# Altium schematic sheet bias supply.SchDoc
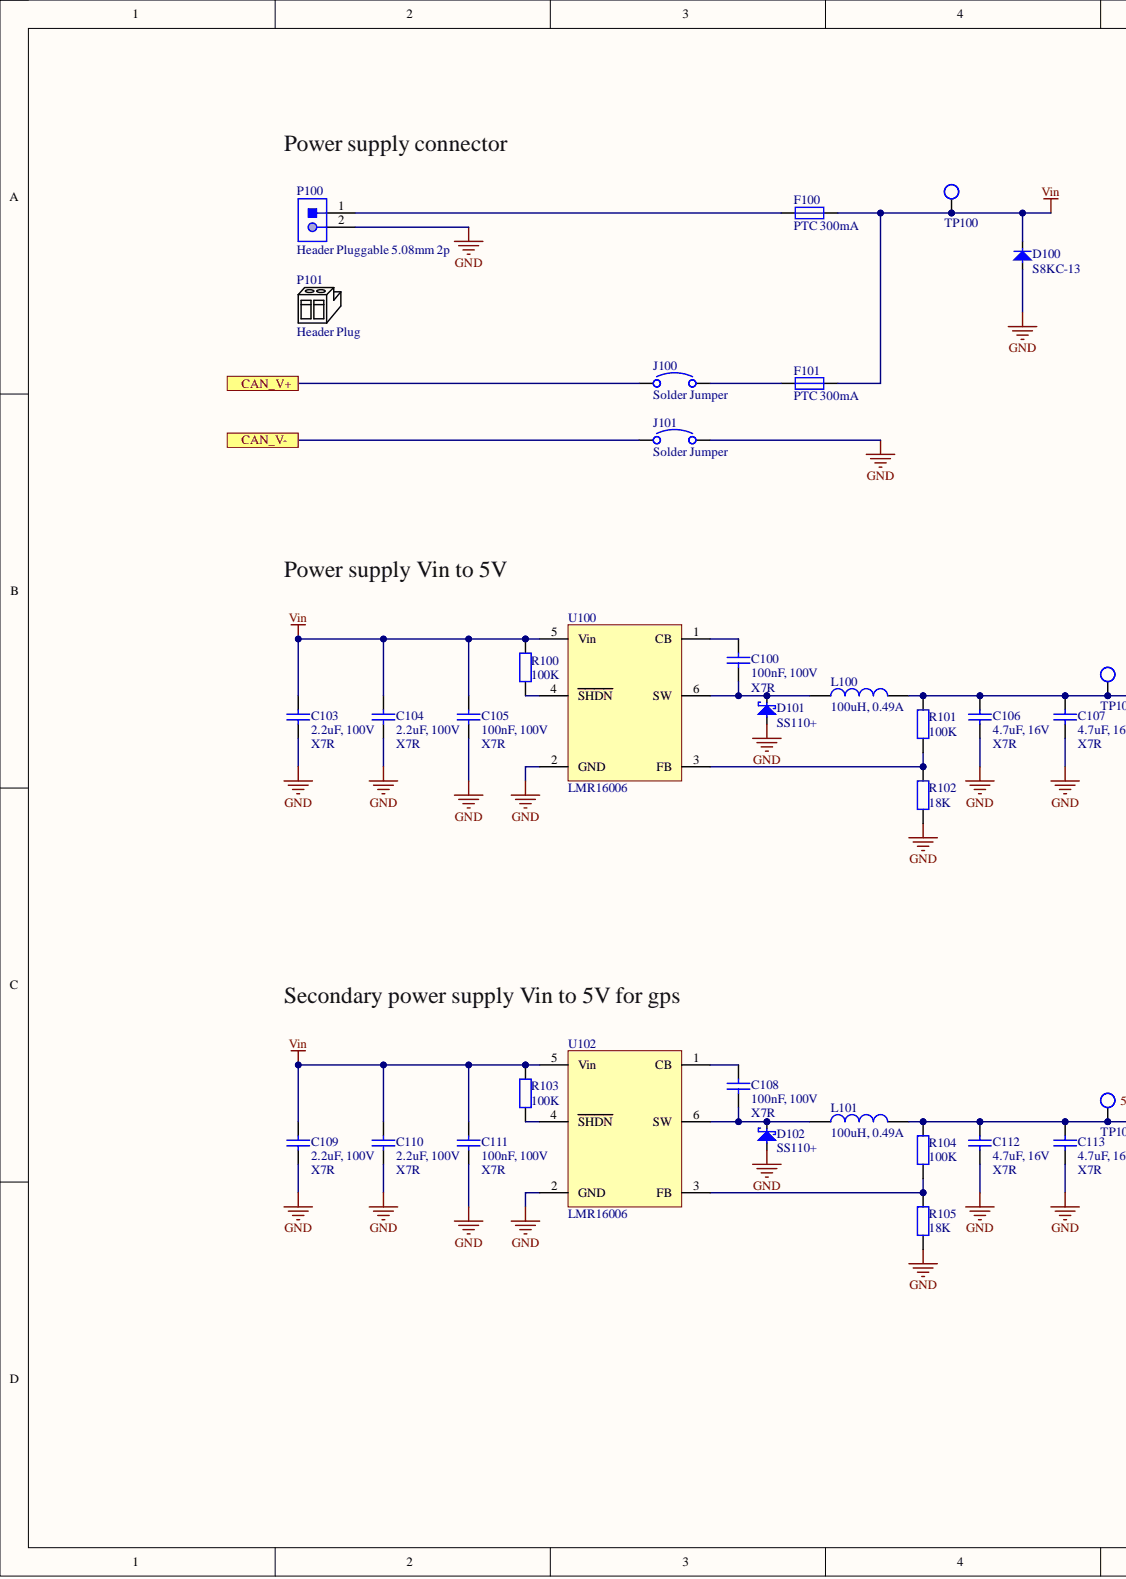
[diagram p0: bias supply.SchDoc, left]
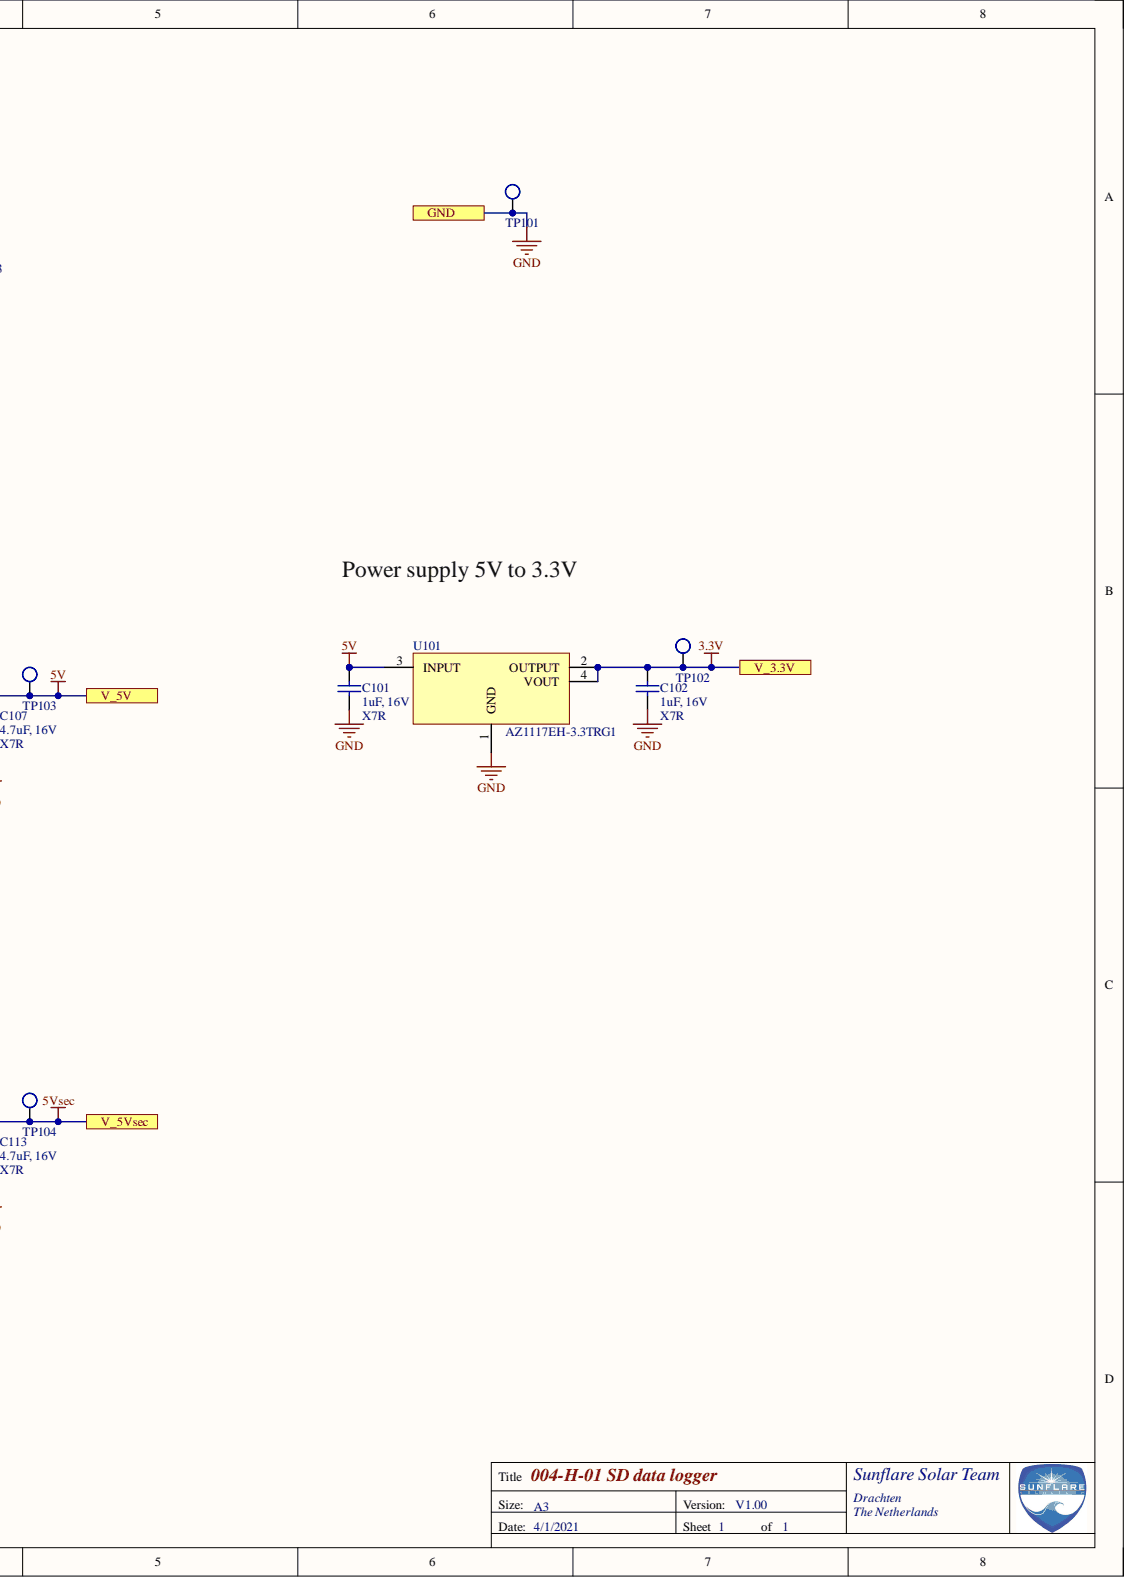
[diagram p1: bias supply.SchDoc, right]

## Parts
C100  100nF, 100V  Ceramic Type: X7R  [CAP_0805]
C101  1uF, 16V  Ceramic Type: X7R  [CAP_0805]
C102  1uF, 16V  Ceramic Type: X7R  [CAP_0805]
C103  2.2uF, 100V  Ceramic Type: X7R  [CAP_1210]
C104  2.2uF, 100V  Ceramic Type: X7R  [CAP_1210]
C105  100nF, 100V  Ceramic Type: X7R  [CAP_0805]
C106  4.7uF, 16V  Ceramic Type: X7R  [CAP_0805]
C107  4.7uF, 16V  Ceramic Type: X7R  [CAP_0805]
C108  100nF, 100V  Ceramic Type: X7R  [CAP_0805]
C109  2.2uF, 100V  Ceramic Type: X7R  [CAP_1210]
C110  2.2uF, 100V  Ceramic Type: X7R  [CAP_1210]
C111  100nF, 100V  Ceramic Type: X7R  [CAP_0805]
C112  4.7uF, 16V  Ceramic Type: X7R  [CAP_0805]
C113  4.7uF, 16V  Ceramic Type: X7R  [CAP_0805]
D100  S8KC-13  [DIODEDO-214AB]
D101  SS110+  [DIODEDO-214AC]
D102  SS110+  [DIODEDO-214AC]
F100  PTC 300mA  [PTC7451X12N]
F101  PTC 300mA  [PTC7451X12N]
J100  Solder Jumper  [SolderJumer]  (no BOM)
J101  Solder Jumper  [SolderJumer]  (no BOM)
L100  100uH, 0.49A  Rated Current: 0.49A  [WE-LQS_4025]
L101  100uH, 0.49A  Rated Current: 0.49A  [WE-LQS_4025]
P100  Header Pluggable 5.08mm 2p  Application: Pluggable  [WE-691313510002]
P101  Header Plug  Type: Plug  (mechanical)
R100  100K  [RES_0805]
R101  100K  [RES_0805]
R102  18K  [RES_0805]
R103  100K  [RES_0805]
R104  100K  [RES_0805]
R105  18K  [RES_0805]
TP100  Test Pad  [TestPad]  (value not drawn)  (no BOM)
TP101  Test Pad  [TestPad]  (value not drawn)  (no BOM)
TP102  Test Pad  [TestPad]  (value not drawn)  (no BOM)
TP103  Test Pad  [TestPad]  (value not drawn)  (no BOM)
TP104  Test Pad  [TestPad]  (value not drawn)  (no BOM)
U100  LMR16006  [SOT95P280X110-6N]
U101  AZ1117EH-3.3TRG1  [FP-SOT223-IPC_A]
U102  LMR16006  [SOT95P280X110-6N]

## Ports
CAN_V+, CAN_V-, GND, V_3.3V, V_5V, V_5Vsec

## Nets
Vin: C103.2 C104.1 C105.2 C109.2 C110.1 C111.2 D100.1 F100.2 F101.2 R100.2 R103.2 TP100.1 U100.5(Vin) U102.5(Vin)
3.3V: C102.1 TP102.1 U101.2(OUTPUT) U101.4(VOUT) ; port V_3.3V
5V: C101.2 C106.2 C107.1 L100.2 R101.2 TP103.1 U101.3(INPUT) ; port V_5V
5Vsec: C112.2 C113.1 L101.2 R104.2 TP104.1 ; port V_5Vsec
GND: C101.1 C102.2 C103.1 C104.2 C105.1 C106.1 C107.2 C109.1 C110.2 C111.1 C112.1 C113.2 D100.2 D101.2 D102.2 J101.2 P100.2 R102.1 R105.1 TP101.1 U100.2(GND) U101.1(GND) U102.2(GND) ; port GND
NetC100_1: C100.1 U100.1(CB)
NetC100_2: C100.2 D101.1 L100.1 U100.6(SW)
NetC108_1: C108.1 U102.1(CB)
NetC108_2: C108.2 D102.1 L101.1 U102.6(SW)
NetF100_1: F100.1 P100.1
NetF101_1: F101.1 J100.2
NetJ100_1: J100.1
NetJ101_1: J101.1
NetR100_1: R100.1 U100.4(SHDN)
NetR101_1: R101.1 R102.2 U100.3(FB)
NetR103_1: R103.1 U102.4(SHDN)
NetR104_1: R104.1 R105.2 U102.3(FB)

## Library data of the parts
C100 C105 C108 C111 = CAP_0805_100nF_100V: WCAP-CSGP Multilayer Ceramic Chip Capacitor, General Purpose, size 0805, X7R, 100nF, 100V; Manufacturer: Wurth Elektronik; Manufacturer Part Number: 885012207128; Capacitance Tolerance: +/-10%; Dissipation Factor: #NAME?; Isolation Resistance: >=1GOhm; Rated Voltage: 100VDC; Technical Reference: X7R0805104K100DFCT10000; Capacitance: 100nF; Component Kind: Standard; ComponentLink1Description: Manufacturer; ComponentLink1URL: http://www.we-online.de; ComponentLink2Description: Datasheet; ComponentLink2URL: https://www.we-online.com/catalog/datasheet/885012207128.pdf; Height: 1.25mm; Library Name: 004-H-01 SD data logger.SchLib; Mounting Technology: SMT; Operating Temperature: -55 to +125degC; Packaging: Tape and Reel; Published Date: May 2020; Size: 0805; Supplier 1: Farnell; Supplier Part Number 1: 2812440
C101 C102 = CAP_0805_1uF_16V: WCAP-CSGP Multilayer Ceramic Chip Capacitor, General Purpose, size 0805, X7R, 1uF, 16V; Manufacturer: Wurth Elektronik; Manufacturer Part Number: 885012207051; Capacitance Tolerance: +/-10%; Dissipation Factor: #NAME?; Isolation Resistance: >=0.5GOhm; Rated Voltage: 16VDC; Technical Reference: X7R0805105K016DFCT10000; Capacitance: 1uF; Component Kind: Standard; ComponentLink1Description: Manufacturer; ComponentLink1URL: http://www.we-online.de; ComponentLink2Description: Datasheet; ComponentLink2URL: https://www.we-online.com/catalog/datasheet/885012207051.pdf; Height: 1.25mm; Library Name: 004-H-01 SD data logger.SchLib; Mounting Technology: SMT; Operating Temperature: -55 to +125degC; Packaging: Tape and Reel; Published Date: May 2020; Size: 0805; Supplier 1: Farnell; Supplier Part Number 1: 2534058
C103 C104 C109 C110 = CAP_1210_2.2uF_100V: WCAP-CSGP Multilayer Ceramic Chip Capacitor, General Purpose, size 1210, X7R, 2.2uF, 100V; Manufacturer: Wurth Elektronik; Manufacturer Part Number: 885012209071; Capacitance Tolerance: +/-10%; Dissipation Factor: #NAME?; Isolation Resistance: >=0.05GOhm; Rated Voltage: 100VDC; Technical Reference: X7R1210225K100DFCT10000; Capacitance: 2.2uF; Component Kind: Standard; ComponentLink1Description: Manufacturer; ComponentLink1URL: http://www.we-online.de; ComponentLink2Description: Datasheet; ComponentLink2URL: https://www.we-online.com/catalog/datasheet/885012209071.pdf; Height: 2.5mm; Library Name: 004-H-01 SD data logger.SchLib; Mounting Technology: SMT; Operating Temperature: -55 to +125degC; Packaging: Tape and Reel; Published Date: May 2020; Size: 1210
C106 C107 C112 C113 = CAP_0805_4.7uF_16V: WCAP-CSGP Multilayer Ceramic Chip Capacitor, General Purpose, size 0805, X7R, 4.7uF, 16V; Manufacturer: Wurth Elektronik; Manufacturer Part Number: 885012207053; Capacitance Tolerance: +/-10%; Dissipation Factor: #NAME?; Isolation Resistance: >=0.02GOhm; Rated Voltage: 16VDC; Technical Reference: X7R0805475K016DFCT10000; Capacitance: 4.7uF; Component Kind: Standard; ComponentLink1Description: Manufacturer; ComponentLink1URL: http://www.we-online.de; ComponentLink2Description: Datasheet; ComponentLink2URL: https://www.we-online.com/catalog/datasheet/885012207053.pdf; Height: 1.25mm; Library Name: 004-H-01 SD data logger.SchLib; Mounting Technology: SMT; Operating Temperature: -55 to +125degC; Packaging: Tape and Reel; Published Date: May 2020; Size: 0805; Supplier 1: Farnell; Supplier Part Number 1: 2495191
D100 = Diode S8KC-13: Diode; Manufacturer: Diodes inc; Component Kind: Standard; Library Name: 004-H-01 SD data logger.SchLib; Manufacturer Part No: S8KC-13; Supplier 1: Farnell; Supplier Part Number 1: 3127431
D101 D102 = Diode Schottky SS110+: Diode Schottky; Manufacturer: Multicomp; Component Kind: Standard; Library Name: 004-H-01 SD data logger.SchLib; Manufacturer Part No: SS110+; Supplier 1: Farnell; Supplier Part Number 1: 4085140
F100 F101 = PTC 300mA: Fuse; Manufacturer: Multicomp Pro; Manufacturer Part No: MC33189; Component Kind: Standard; Library Name: 004-H-01 SD data logger.SchLib; Supplier 1: Farnell; Supplier Part Number 1: 1800748
J100 J101 = SolderJumper: Solder jumper open; Library Name: 004-H-01 SD data logger.SchLib; Manufacturer: -; Manufacturer Part No: -
L100 L101 = Inductor 100uH 0.49A 1043mOhm: WE-LQS SMT Power Inductor, size 4025, 100uH, 0.49A; Manufacturer: Wurth Elektronik; Manufacturer Part Number: 74404043101A; Saturation Current: 0.29A; Self Resonant Frequency: 11MHz; Component Kind: Standard; ComponentLink1Description: Manufacturer; ComponentLink1URL: http://www.we-online.de; ComponentLink2Description: Datasheet; ComponentLink2URL: https://www.we-online.com/catalog/datasheet/74404043101A.pdf; DC Resistance: 1043mOhm; Inductance: 100uH; Library Name: 004-H-01 SD data logger.SchLib; Mounting Technology: SMT; Operating Temperature: -40 to +125degC; Packaging: Tape and Reel; Published Date: May 2020; Size: 4025; Supplier 1: Farnell; Supplier Part Number 1: 2749073
P100 = Header Pluggable 5.08mm 2p: WR-TBL Serie 313 Close Horizontal PCB Header, Pluggable, pitch 5.08mm, 2p; Part Number: 691313510002; Compliance: RoHS; Modularity: No; Pin Number: 2; Rated Current [UL]: 20A; Working Voltage [UL]: 300VAC; Component Kind: Standard; ComponentLink1Description: Manufacturer; ComponentLink1URL: http://www.we-online.de; ComponentLink2Description: Datasheet; ComponentLink2URL: https://katalog.we-online.de/em/datasheet/691313510002.pdf; Library Name: 004-H-01 SD data logger.SchLib; Mounting Technology: THT; Operating Temperature: -40 to +105degC; Packaging: Box; PCB/Cable/Panel: PCB; Pitch: 5.08mm; Published Date: October 2019; Supplier 1: Farnell; Supplier Part Number 1: 1641993; Type: Horizontal; Publisher: Wurth Elektronik
P101 = Plug Pluggable 5.08mm 2p: WR-TBL Series 351 - 5.08 mm Vertical, pitch 5.08mm, 2p; Part Number: 691351500002; Compliance: RoHS; Modularity: No; PCB/Cable/Panel: Cable; Pin Number: 2; Rated Current [UL]: 20A; Working Voltage [UL]: 300VAC; Application: Pluggable; ComponentLink1Description: Manufacturer; ComponentLink1URL: http://www.we-online.de; ComponentLink2Description: Datasheet; ComponentLink2URL: https://katalog.we-online.de/em/datasheet/691313510002.pdf; Library Name: 004-H-01 SD data logger.SchLib; Operating Temperature: -40 to +105degC; Packaging: Box; Pitch: 5.08mm; Published Date: October 2019; Supplier 1: Farnell; Supplier Part Number 1: 1641952; Publisher: Wurth Elektronik
R100 R101 R103 R104 = RES_0805_100K: Thick Film Resistor, size 0805, 1%; Rated Power: 0.125W; Resistance Tolerance: +/-1%; Component Kind: Standard; Height: 0.6mm; Length: 2mm; Library Name: 004-H-01 SD data logger.SchLib; Mounting Technology: SMT; Operating Temperature: -55 to +155degC; Packaging: Tape and Reel; Resistance: 100K; Size: 0805; Width: 1.25mm
R102 R105 = RES_0805_18K: Thick Film Resistor, size 0805, 1%; Rated Power: 0.125W; Resistance Tolerance: +/-1%; Component Kind: Standard; Height: 0.6mm; Length: 2mm; Library Name: 004-H-01 SD data logger.SchLib; Mounting Technology: SMT; Operating Temperature: -55 to +155degC; Packaging: Tape and Reel; Resistance: 18K; Size: 0805; Width: 1.25mm
TP100-TP104 = Test Pad: Test pad 2mm; Library Name: 004-H-01 SD data logger.SchLib; Manufacturer: -; Manufacturer Part No: -
U100 U102 = LMR16006: DC-DC Switching Buck (Step Down) Regulator, Adjustable, 4V-60Vin, 800mV-55Vout, 600mAout, TSOT-23-6; Manufacturer: Texas Instruments; Manufacturer Part No: LMR16006XDDCT; Component Kind: Standard; Library Name: 004-H-01 SD data logger.SchLib; Supplier 1: Farnell; Supplier Part Number 1: 3121632
U101 = AZ1117EH-3.3TRG1: IC REG LINEAR 3.3V 1A SOT223; Manufacturer: Diodes Inc; Accuracy: 1%; Dropout Voltage: 1.1V; Max Input Voltage: 13V; Number of Outputs: 1; Operating Supply Current: 3.5mA; Output Type: Fixed; Polarity: Positive; Power Supply Rejection Ratio (PSRR): 70dB; Reference Voltage: 1.262V; Case/Package: TO-261-4; Component Kind: Standard; Contact Plating: Tin; Lead Free: Lead Free; Library Name: 004-H-01 SD data logger.SchLib; Manufacturer Part Number: AZ1117EH-3.3TRG1; Max Operating Temperature: 125°C; Max Output Current: 1A; Min Operating Temperature: -40°C; Mount: Surface Mount; Number of Pins: 3; Number of Regulators: 1; Output Current: 1A; Output Voltage: 3.3V; Package Quantity: 4000; Packaging: Tape and Reel; Quiescent Current: 3.5mA; Weight: 0.000282oz
